# Altium schematic sheet ArduinoSensorsShield-s1.SchDoc(ArduinoSensorsShield-s1)
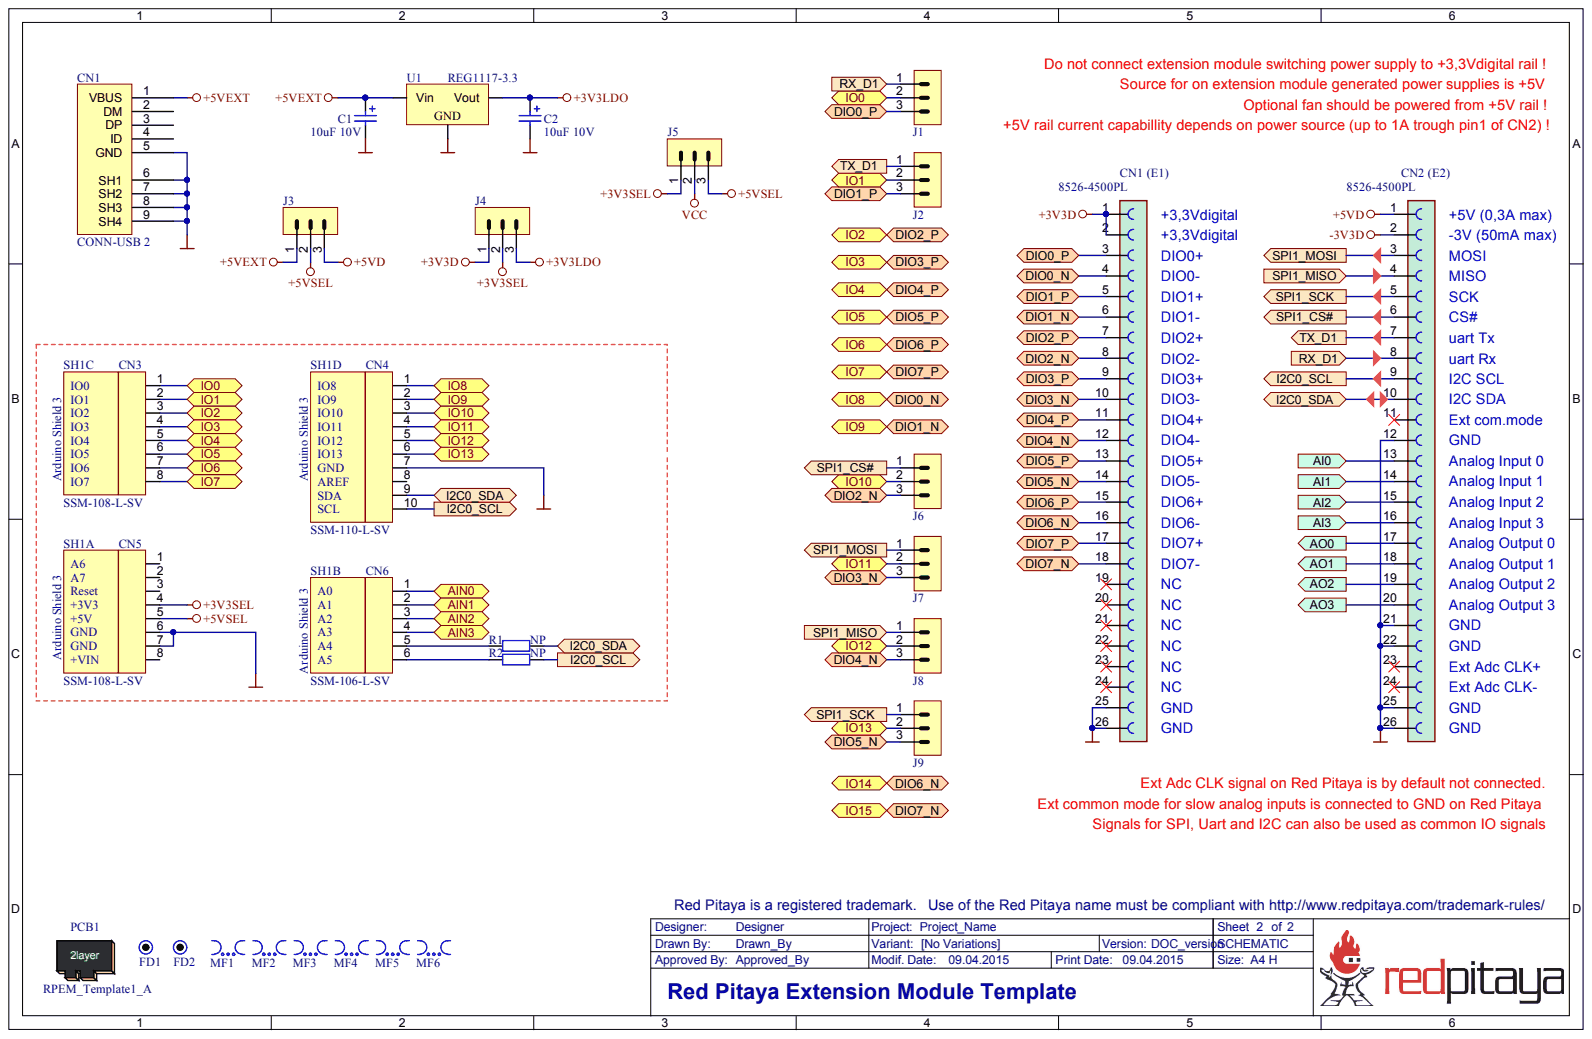
[diagram p0: ArduinoSensorsShield-s1.SchDoc]

## Parts
C1  10uF 10V  [TC3216-1206]
C2  10uF 10V  [TC3216-1206]
CN1  CONN-USB 2  [micro-USB]
CN1_(E1)  8526-4500PL  [CN_MPE-GARRY100-2-026-0-NFX-YS0-2331]
CN2_(E2)  8526-4500PL  [CN_MPE-GARRY100-2-026-0-NFX-YS0-2331]
CN3  SSM-108-L-SV  [SSM-108-L-SV]
CN4  SSM-110-L-SV  [SSM-110-L-SV]
CN5  SSM-108-L-SV  [SSM-108-L-SV]
CN6  SSM-106-L-SV  [SSM-106-L-SV]
FD1  R  [FIDRTB40]  (value not drawn)
FD2  R  [FIDRTB40]  (value not drawn)
J1  JMP_1x2  [H-D-1x3]  (value not drawn)
J2  JMP_1x2  [H-D-1x3]  (value not drawn)
J3  JMP_1x2  [H-D-1x3]  (value not drawn)
J4  JMP_1x2  [H-D-1x3]  (value not drawn)
J5  JMP_1x2  [H-D-1x3]  (value not drawn)
J6  JMP_1x2  [H-D-1x3]  (value not drawn)
J7  JMP_1x2  [H-D-1x3]  (value not drawn)
J8  JMP_1x2  [H-D-1x3]  (value not drawn)
J9  JMP_1x2  [H-D-1x3]  (value not drawn)
MF1  MILL_EAR2.0S  [MILLEAR2.0S-C]  (value not drawn)
MF2  MILL_EAR2.0S  [MILLEAR2.0S-C]  (value not drawn)
MF3  MILL_EAR2.0S  [MILLEAR2.0S-C]  (value not drawn)
MF4  MILL_EAR2.0S  [MILLEAR2.0S-C]  (value not drawn)
MF5  MILL_EAR2.0S  [MILLEAR2.0S-C]  (value not drawn)
MF6  MILL_EAR2.0S  [MILLEAR2.0S-C]  (value not drawn)
PCB1  RPEM_Template1_A  [PCB_2L-SS]
R1  NP  [CR1608-0603]
R2  NP  [CR1608-0603]
SH1A  Arduino Shield 3  [Arduino-Shield 3]
SH1B  Arduino Shield 3  [Arduino-Shield 3]
SH1C  Arduino Shield 3  [Arduino-Shield 3]
SH1D  Arduino Shield 3  [Arduino-Shield 3]
U1  REG1117-3.3  [DSO-G3]

## Ports
AI0, AI1, AI2, AI3, AIN0, AIN1, AIN2, AIN3, AO0, AO1, AO2, AO3, DIO0_N, DIO0_P, DIO1_N, DIO1_P, DIO2_N, DIO2_P, DIO3_N, DIO3_P, DIO4_N, DIO4_P, DIO5_N, DIO5_P, DIO6_N, DIO6_P, DIO7_N, DIO7_P, I2C0_SCL, I2C0_SDA, IO0, IO1, IO2, IO3, IO4, IO5, IO6, IO7, IO8, IO9, IO10, IO11, IO12, IO13, IO14, IO15, RX_D1, SPI1_CS#, SPI1_MISO, SPI1_MOSI, SPI1_SCK, TX_D1

## Nets
+3V3D: CN1_(E1).1 CN1_(E1).2 J4.1
+3V3LDO: C2.1 J4.3 U1.2
+3V3SEL: CN5.4 J4.2 J5.1
+5VD: CN2_(E2).1 J3.3
+5VEXT: C1.1 CN1.1(VBUS) J3.1 U1.3
+5VSEL: CN5.5 J3.2 J5.3
-3V3D: CN2_(E2).2
GND: C1.2 C2.2 CN1.5(GND) CN1.6(SH1) CN1.7(SH2) CN1.8(SH3) CN1.9(SH4) CN1_(E1).25 CN1_(E1).26 CN2_(E2).12 CN2_(E2).21 CN2_(E2).22 CN2_(E2).25 CN2_(E2).26 CN4.7 CN5.6 CN5.7 U1.1
VCC: J5.2
AI0: CN2_(E2).13 ; port AI0
AI1: CN2_(E2).14 ; port AI1
AI2: CN2_(E2).15 ; port AI2
AI3: CN2_(E2).16 ; port AI3
AIN0: CN6.1 ; port AIN0
AIN1: CN6.2 ; port AIN1
AIN2: CN6.3 ; port AIN2
AIN3: CN6.4 ; port AIN3
AO0: CN2_(E2).17 ; port AO0
AO1: CN2_(E2).18 ; port AO1
AO2: CN2_(E2).19 ; port AO2
AO3: CN2_(E2).20 ; port AO3
DIO0_N: CN1_(E1).4 CN4.1 ; port DIO0_N, port IO8
DIO0_P: CN1_(E1).3 J1.3 ; port DIO0_P
DIO1_N: CN1_(E1).6 CN4.2 ; port DIO1_N, port IO9
DIO1_P: CN1_(E1).5 J2.3 ; port DIO1_P
DIO2_N: CN1_(E1).8 J6.3 ; port DIO2_N
DIO2_P: CN1_(E1).7 CN3.3 ; port DIO2_P, port IO2
DIO3_N: CN1_(E1).10 J7.3 ; port DIO3_N
DIO3_P: CN1_(E1).9 CN3.4 ; port DIO3_P, port IO3
DIO4_N: CN1_(E1).12 J8.3 ; port DIO4_N
DIO4_P: CN1_(E1).11 CN3.5 ; port DIO4_P, port IO4
DIO5_N: CN1_(E1).14 J9.3 ; port DIO5_N
DIO5_P: CN1_(E1).13 CN3.6 ; port DIO5_P, port IO5
DIO6_N: CN1_(E1).16 ; port DIO6_N, port IO14
DIO6_P: CN1_(E1).15 CN3.7 ; port DIO6_P, port IO6
DIO7_N: CN1_(E1).18 ; port DIO7_N, port IO15
DIO7_P: CN1_(E1).17 CN3.8 ; port DIO7_P, port IO7
I2C0_SCL: CN2_(E2).9 CN4.10 R2.2 ; port I2C0_SCL
I2C0_SDA: CN2_(E2).10 CN4.9 R1.2 ; port I2C0_SDA
IO0: CN3.1 J1.2 ; port IO0
IO1: CN3.2 J2.2 ; port IO1
IO10: CN4.3 J6.2 ; port IO10
IO11: CN4.4 J7.2 ; port IO11
IO12: CN4.5 J8.2 ; port IO12
IO13: CN4.6 J9.2 ; port IO13
RX_D1: CN2_(E2).8 J1.1 ; port RX_D1
SPI1_CS#: CN2_(E2).6 J6.1 ; port SPI1_CS#
SPI1_MISO: CN2_(E2).4 J8.1 ; port SPI1_MISO
SPI1_MOSI: CN2_(E2).3 J7.1 ; port SPI1_MOSI
SPI1_SCK: CN2_(E2).5 J9.1 ; port SPI1_SCK
TX_D1: CN2_(E2).7 J2.1 ; port TX_D1
NetCN6_5: CN6.5 R1.1
NetCN6_6: CN6.6 R2.1

Not connected: CN1 2(DM) 3(DP) 4(ID); CN1_(E1) 19 20 21 22 23 24; CN2_(E2) 11 23 24; CN4 8; CN5 1 2 3 8

## Library data of the parts
C1 C2 = Cap Pol: Polarized Capacitor; Component Kind: Standard; Library Name: Main_Schlib.SchLib
CN1_(E1) CN2_(E2) = CN_MPE-GARRY_FEMHDR_100-2-026-0-NFX-YS0-2331  : Connector FEMHDR Board-Board P2,54mm Pcb Straight thd; Code: 108; CompHeight(mm): 21,2; Current: 1A; Database Table Name: IDC_HDR; Decade: 2; Gender: Fem; Ident: R; LastRevisionNote: nov vnos; Level: 5; Prefix: CN_; Qmult: 1; Sort: 612; Value2: 26pin Fem 50V 1A; Voltage: 50V; Component Kind: Standard; CompType: Connector; Extra: Board-Board; Extra2: P2,54mm; Extra3: Pcb Straight thd; Farnell: 9138650; ID: 108; LastRevisionDate: 28.4.2014; Library Name: Connectors.DbLib; Manufacturer: MPE-Garry; Manufacturer Part Number: 100-2-026-0-NFX-YS0-2331; MOQ: 1; Package: CN FEMHDR 2x13 P2,54; Part Number: CN_MPE-GARRY_FEMHDR_100-2-026-0-NFX-YS0-2331; PinCount: 26; Price: 2; Series: FEMHDR; Size: 2X13F; Supplier 1: Farnell; Supplier 2: Digi-key; Supplier 3: Mouser; Supplier 4: Arrow; Tech: THD; Value: 8526-4500PL; Author: Zarko Lestan
CN3 CN5 = CONN-1x8pin: Connector 1x8; Component Kind: Standard; Farnell: 2418196; Library Name: Main_Schlib.SchLib
CN4 = CONN-1x10pin: Connector 1x10; Component Kind: Standard; Farnell: 2418201; Library Name: Main_Schlib.SchLib
CN6 = CONN-1x6pin: Connector 1x6; Component Kind: Standard; Farnell: 2418192; Library Name: Main_Schlib.SchLib
FD1 FD2 = FID_RTB: Fiducial top&bottom round 1.27mm; Code: 4; Database Table Name: PCB; Decade: 0; LastRevisionNote: nov vnos; Prefix: FID; Qmult: 1; Sort: 60; Structure: TB; Tech: _PCB; Component Kind: Standard; CompType: Fiducial; DescriptionL: Fiducial top&bottom round 1.27mm; ID: 4; Ident: R; LastRevisionDate: 19.3.2012; Level: 0; Library Name: Mechanical.DbLib; MOQ: 1; Package: Fiducial top&bot Round; Part Number: FID_RTB; Price: 0; SchRevDate: 29.11.2011; Value: R; Author: Zarko Lestan
MF1-MF6 = MILL_EAR2.0S: Milling ear 2.0mm width single side punched; Code: 6; CompType: Mech Feature; Database Table Name: PCB; Decade: 0; Ident: R; LastRevisionNote: nov vnos; Prefix: MILL; Qmult: 1; Sort: 65; Tech: _PCB; Value2: mill with Ø2.0mm; Component Kind: Standard (NoBOM); DescriptionL: Milling ear 2.0mm width single side punched; ID: 6; LastRevisionDate: 30.11.2011; Level: 0; Library Name: Mechanical.DbLib; MOQ: 1; Package: NoPNP; Part Number: MILL_EAR2.0S; Price: 0; Value: EAR2.0S; Author: Zarko Lestan
PCB1 = PCB_RPEM_Template1_A_FR4_2L-SS_1,6mm: Printed circuit board 2 layer 52x60mm Panel 2x2 106x137mm; Code: 4625; CompHeight(mm): 1,6; CompType: Pcb; Decade: 0; Extra: 35-35um Cu; ID: 87; Ident: R; LastRevisionNote: nov vnos; Qmult: 1; Sort: 50; Structure: 2L; Structure2: SS; Tech: _PCB; Value2: FR4 1,6mm 35-35um Cu; Component Kind: Standard; Database Table Name: PCB; DescriptionL: Printed circuit board 2 layer; Extra2: Panel 2x2; Extra3: 106x137mm; LastRevisionDate: 23.7.2014; Level: 0; Library Name: Mechanical.DbLib; Material: FR4; MOQ: 1; Package: PCB; Part Number: PCB_RPEM_Template1_A_FR4_2L-SS_1,6mm; Prefix: PCB; Price: 0; SchRevDate: 29.11.2011; Size: 52x60mm; Value: RPEM_Template1_A; Author: RedPitaya
R1 R2 = Res: Resistor; Component Kind: Standard; Library Name: Main_Schlib.SchLib
U1 = LDO-1117: Component Kind: Standard; Farnell: 1212355; Library Name: Main_Schlib.SchLib
CN1 = CONN-USB 2: Component Kind: Standard; Library Name: Main_Schlib.SchLib
J1-J9 = JMP_1x2: Component Kind: Standard; Library Name: Main_Schlib.SchLib
SH1A SH1B SH1C SH1D = Arduino Shield 3: Component Kind: Standard; Library Name: Main_Schlib.SchLib
